# Altium schematic sheet keyb_mpu.SchDoc(keyb_mpu)
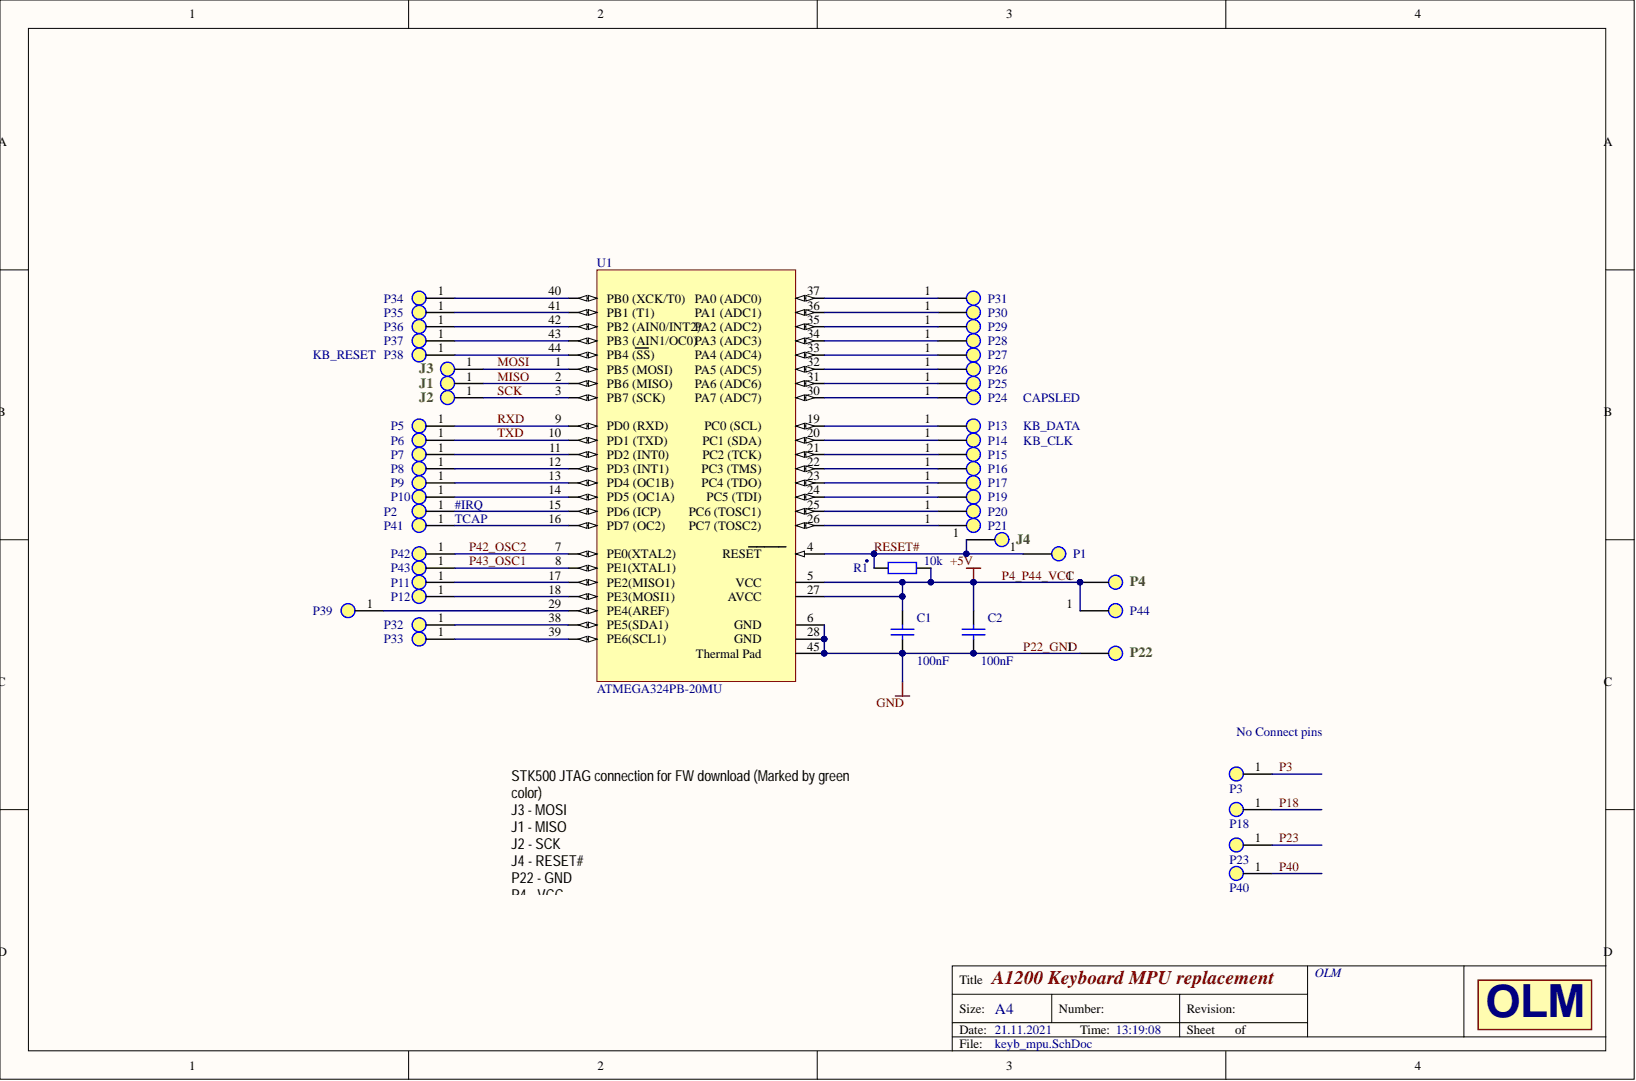
[diagram p0: keyb_mpu.SchDoc]

## Parts
C1  value 100nF  [SM/C_0402]  (hidden comment: Capacitor)
C2  value 100nF  [SM/C_0402]  (hidden comment: Capacitor)
J1  Перемычка  [PLS-1SMD]  (value not drawn)
J2  Перемычка  [PLS-1SMD]  (value not drawn)
J3  Перемычка  [PLS-1SMD]  (value not drawn)
J4  Перемычка  [PLS-1SMD]  (value not drawn)
P1  Перемычка  [PLCC_1_PIN]  (value not drawn)
P2  Note: #IRQ  [PLCC_1_PIN]  (hidden comment: Pin)
P3  Pin  [PLCC_1_PIN]  (value not drawn)
P4  Перемычка  [PLCC_1_PIN]  (value not drawn)
P5  Pin  [PLCC_1_PIN]  (value not drawn)
P6  Pin  [PLCC_1_PIN]  (value not drawn)
P7  Pin  [PLCC_1_PIN]  (value not drawn)
P8  Pin  [PLCC_1_PIN]  (value not drawn)
P9  Pin  [PLCC_1_PIN]  (value not drawn)
P10  Pin  [PLCC_1_PIN]  (value not drawn)
P11  Pin  [PLCC_1_PIN]  (value not drawn)
P12  Pin  [PLCC_1_PIN]  (value not drawn)
P13  Pin  [PLCC_1_PIN]  (value not drawn)
P14  Pin  [PLCC_1_PIN]  (value not drawn)
P15  Pin  [PLCC_1_PIN]  (value not drawn)
P16  Pin  [PLCC_1_PIN]  (value not drawn)
P17  Pin  [PLCC_1_PIN]  (value not drawn)
P18  Pin  [PLCC_1_PIN]  (value not drawn)
P19  Pin  [PLCC_1_PIN]  (value not drawn)
P20  Pin  [PLCC_1_PIN]  (value not drawn)
P21  Pin  [PLCC_1_PIN]  (value not drawn)
P22  Перемычка  [PLCC_1_PIN]  (value not drawn)
P23  Pin  [PLCC_1_PIN]  (value not drawn)
P24  Pin  [PLCC_1_PIN]  (value not drawn)
P25  Pin  [PLCC_1_PIN]  (value not drawn)
P26  Pin  [PLCC_1_PIN]  (value not drawn)
P27  Pin  [PLCC_1_PIN]  (value not drawn)
P28  Pin  [PLCC_1_PIN]  (value not drawn)
P29  Pin  [PLCC_1_PIN]  (value not drawn)
P30  Pin  [PLCC_1_PIN]  (value not drawn)
P31  Pin  [PLCC_1_PIN]  (value not drawn)
P32  Pin  [PLCC_1_PIN]  (value not drawn)
P33  Pin  [PLCC_1_PIN]  (value not drawn)
P34  Pin  [PLCC_1_PIN]  (value not drawn)
P35  Pin  [PLCC_1_PIN]  (value not drawn)
P36  Pin  [PLCC_1_PIN]  (value not drawn)
P37  Pin  [PLCC_1_PIN]  (value not drawn)
P38  Pin  [PLCC_1_PIN]  (value not drawn)
P39  Pin  [PLCC_1_PIN]  (value not drawn)
P40  Pin  [PLCC_1_PIN]  (value not drawn)
P41  Note: TCAP  [PLCC_1_PIN]  (hidden comment: Pin)
P42  Перемычка  [PLCC_1_PIN]  (value not drawn)
P43  Перемычка  [PLCC_1_PIN]  (value not drawn)
P44  Перемычка  [PLCC_1_PIN]  (value not drawn)
R1  value 10k  [SM/R_0402]  (hidden comment: Resistor)
U1  ATMEGA324PB-20MU  [VQFN-44]

## Nets
MISO: J1.1 U1.2(PB6_(MISO))
MOSI: J3.1 U1.1(PB5_(MOSI))
P3: P3.1
P4_P44_VCC: C1.2 C2.2 P4.1 P44.1 R1.2 U1.5 U1.27
P18: P18.1
P22_GND: C1.1 C2.1 P22.1 U1.6 U1.28 U1.45
P23: P23.1
P40: P40.1
P42_OSC2: P42.1 U1.7(PE0(XTAL2))
P43_OSC1: P43.1 U1.8(PE1(XTAL1))
RESET#: J4.1 P1.1 R1.1 U1.4
RXD: P5.1 U1.9(PD0_(RXD))
SCK: J2.1 U1.3(PB7_(SCK))
TXD: P6.1 U1.10(PD1_(TXD))
NetP2_1: P2.1 U1.15(PD6_(ICP))
NetP7_1: P7.1 U1.11(PD2_(INT0))
NetP8_1: P8.1 U1.12(PD3_(INT1))
NetP9_1: P9.1 U1.13(PD4_(OC1B))
NetP10_1: P10.1 U1.14(PD5_(OC1A))
NetP11_1: P11.1 U1.17(PE2(MISO1))
NetP12_1: P12.1 U1.18(PE3(MOSI1))
NetP13_1: P13.1 U1.19
NetP14_1: P14.1 U1.20
NetP15_1: P15.1 U1.21
NetP16_1: P16.1 U1.22
NetP17_1: P17.1 U1.23
NetP19_1: P19.1 U1.24
NetP20_1: P20.1 U1.25
NetP21_1: P21.1 U1.26
NetP24_1: P24.1 U1.30
NetP25_1: P25.1 U1.31
NetP26_1: P26.1 U1.32
NetP27_1: P27.1 U1.33
NetP28_1: P28.1 U1.34
NetP29_1: P29.1 U1.35
NetP30_1: P30.1 U1.36
NetP31_1: P31.1 U1.37
NetP32_1: P32.1 U1.38(PE5(SDA1))
NetP33_1: P33.1 U1.39(PE6(SCL1))
NetP34_1: P34.1 U1.40(PB0_(XCK/T0))
NetP35_1: P35.1 U1.41(PB1_(T1))
NetP36_1: P36.1 U1.42(PB2_(AIN0/INT2))
NetP37_1: P37.1 U1.43(PB3_(AIN1/OC0))
NetP38_1: P38.1 U1.44(PB4_(SS))
NetP39_1: P39.1 U1.29(PE4(AREF))
NetP41_1: P41.1 U1.16(PD7_(OC2))

## Library data of the parts
C1 C2 = Cap ceramic: Ceramic capacitor SMD; Manufacturer: Samsung; Part: CL10B104KB8NNNL; Component Kind: Standard; Library Name: New.SCHLIB
J1-J4 P1-P44 = Jumper-1: small tac switch; Component Kind: Standard; Library Name: New_home.SCHLIB
R1 = Res2: Part: 22R 5% 0603; Component Kind: Standard; Description: Resistor; LatestRevisionDate: 17-Jul-2002; LatestRevisionNote: Re-released for DXP Platform.; Library Name: Miscellaneous Devices.IntLib; PackageReference: AXIAL-0.4; Published: 8-Jun-2000; Signal Integrity: Res; Simulation: RESISTOR; Publisher: Altium Limited
U1 = ATMEGA324P-20MU: AVR 32K Bytes of In-System Programmable Flash Memory; Code_JEDEC: MO-220-VKKD-1; Component Kind: Standard; ComponentLink1Description: Manufacturer Link; ComponentLink1URL: http://www.atmel.com; ComponentLink2Description: Datasheet; ComponentLink2URL: http://www.atmel.com/dyn/resources/prod_documents/doc2503.pdf; DatasheetVersion: 2503C-AVR-10/02; LatestRevisionDate: 13-Apr-2006; LatestRevisionNote: IPC-7351 Footprint Added.; Library Name: New_home.SCHLIB; PackageDescription: 44-Pin Quad Flat No-Lead 0.5 mm Pitch; PackageReference: 44M1; PackageVersion: revB, Aug-2001; Published: 26-May-2004; Publisher: Altium Limited
